# Altium schematic sheet CPU.SchDoc(CPU)
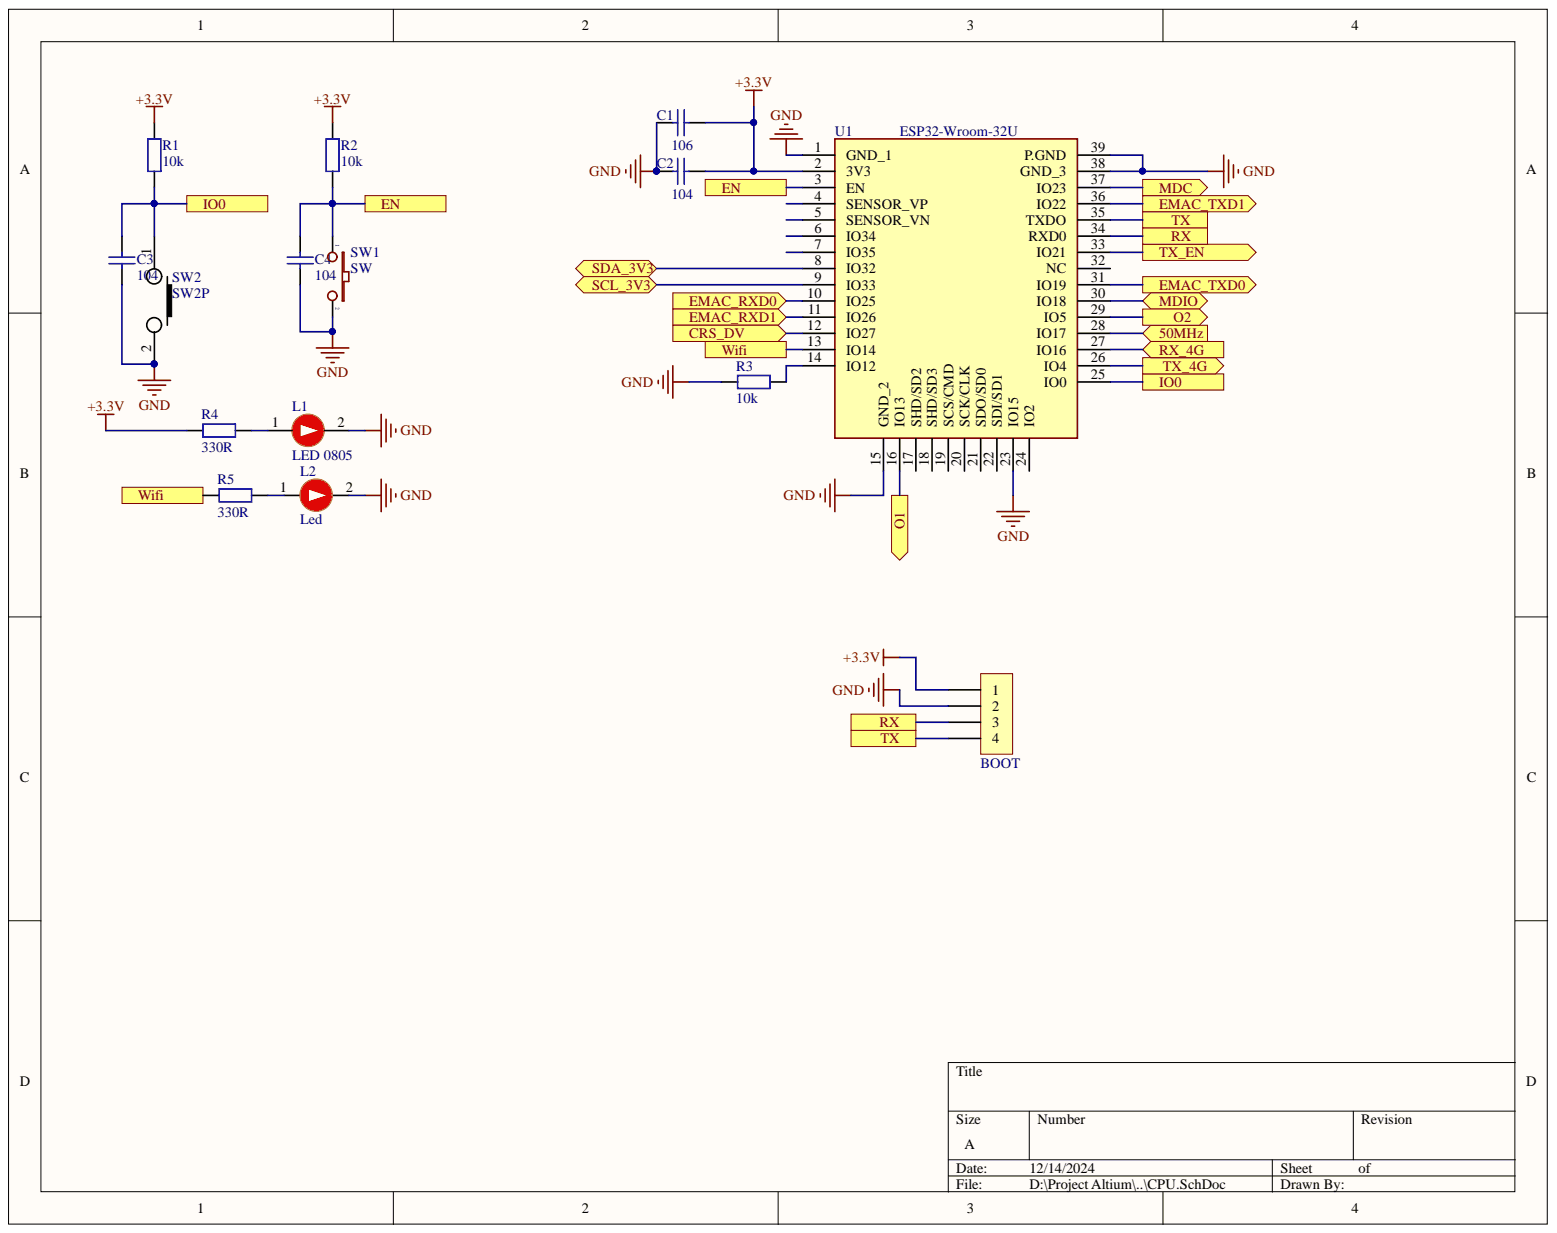
[diagram p0: CPU.SchDoc]

## Parts
C1  106  [tu 0805]
C2  104  [tu 0603]
C3  104  [tu 0603]
C4  104  [tu 0603]
H1  BOOT  [XH2.54M-4]
L1  LED 0805  [LED-0805-Red]
L2  Led  [Led housing]
R1  10k  [tro smd 0603]
R2  10k  [tro smd 0603]
R3  10k  [tro smd 0603]
R4  330R  [tro smd 0603]
R5  330R  [tro smd 0603]
SW1  SW  [SW1-DIP]
SW2  SW2P  [SW_2P_smd]
U1  ESP32-Wroom-32U  [XCVR_ESP32-WROOM-32U]

## Ports
50MHz, CRS_DV, EMAC_RXD0, EMAC_RXD1, EMAC_TXD0, EMAC_TXD1, EN, IO0, MDC, MDIO, O1, O2, RX, RX_4G, SCL_3V3, SDA_3V3, TX, TX_4G, TX_EN, Wifi

## Nets
+3.3V: C1.1 C2.2 H1.1 R1.2 R2.2 R4.2 U1.2(3V3)
GND: C1.2 C2.1 C3.2 C4.2 H1.2 L1.2 L2.2 R3.1 SW1.2 SW2.2 U1.1(GND_1) U1.15(GND_2) U1.23(IO15) U1.38(GND_3) U1.39(P.GND)
50MHz: U1.28(IO17) ; port 50MHz
CRS_DV: U1.12(IO27) ; port CRS_DV
EMAC_RXD0: U1.10(IO25) ; port EMAC_RXD0
EMAC_RXD1: U1.11(IO26) ; port EMAC_RXD1
EMAC_TXD0: U1.31(IO19) ; port EMAC_TXD0
EMAC_TXD1: U1.36(IO22) ; port EMAC_TXD1
EN: C4.1 R2.1 SW1.1 U1.3(EN) ; port EN
IO0: C3.1 R1.1 SW2.1 U1.25(IO0) ; port IO0
MDC: U1.37(IO23) ; port MDC
MDIO: U1.30(IO18) ; port MDIO
O1: U1.16(IO13) ; port O1
O2: U1.29(IO5) ; port O2
RX: H1.3 U1.34(RXD0) ; port RX
RX_4G: U1.27(IO16) ; port RX_4G
SCL_3V3: U1.9(IO33) ; port SCL_3V3
SDA_3V3: U1.8(IO32) ; port SDA_3V3
TX: H1.4 U1.35(TXDO) ; port TX
TX_4G: U1.26(IO4) ; port TX_4G
TX_EN: U1.33(IO21) ; port TX_EN
Wifi: R5.2 U1.13(IO14) ; port Wifi
NetL1_1: L1.1 R4.1
NetL2_1: L2.1 R5.1
NetR3_2: R3.2 U1.14(IO12)

Not connected: U1 4(SENSOR_VP) 5(SENSOR_VN) 6(IO34) 7(IO35) 17(SHD/SD2) 18(SHD/SD3) 19(SCS/CMD) 20(SCK/CLK) 21(SDO/SD0) 22(SDI/SD1) 24(IO2) 32(NC)

## Library data of the parts
C1 = Tu 0805 106 16V 10%: Dán; Ten_Linh_Kien: Tụ 0805 106-10uF 10% 16V; So_Chan: 2; Ma_Hang: MH004553; Don_Vi: Con; Library Name: Tu dien.SchLib; Component Kind: Standard; Chung_Loai: Dán
C2-C4 = Tu 0603 104 50V 10%: Dán; Ten_Linh_Kien: Tụ 0603 104-100nF 10% 50V; So_Chan: 2; Ma_Hang: MH004568; Don_Vi: Con; Library Name: Tu dien.SchLib; Component Kind: Standard; Chung_Loai: Dán
H1 = Header 4P 2.54 Duc Thang: Cắm; Ten_Linh_Kien: Header 4P XH2.54-4P Đực Thẳng; Ma_Hang: MH001285; Don_Vi: Con; Library Name: Header.SchLib; Component Kind: Standard; Chung_Loai: Cắm
L1 = LED 0805 Do: Dán; Ten_Linh_Kien: LED 0805 Màu Đỏ (Red); Ma_Hang: MH000897; Don_Vi: Con; Library Name: Led.SchLib; Component Kind: Standard; Chung_Loai: Dán
L2 = Led housing: Library Name: test.SchLib; Component Kind: Standard
R1 R2 = Tro 0603 1% 10k: Dán; Ten_Linh_Kien: Trở 0603 1% 10k; So_Chan: 2; Ma_Hang: MH006333; Don_Vi: Con; Library Name: Dien Tro.SchLib; Component Kind: Standard; Chung_Loai: Dán
R3 = Tro 0603 5% 10k: Dán; Ten_Linh_Kien: Trở 0603 5% 10k; So_Chan: 2; Ma_Hang: MH006316; Don_Vi: Con; Library Name: Dien Tro.SchLib; Component Kind: Standard; Chung_Loai: Dán
R4 R5 = Tro 0603 5% 330R: Dán; Ten_Linh_Kien: Trở 0603 5% 330R; So_Chan: 2; Ma_Hang: MH006910; Don_Vi: Con; Library Name: Dien Tro.SchLib; Component Kind: Standard; Chung_Loai: Dán
SW1 = SW2: Switch button 2 pin; Value: SW chip 2 pin; Library Name: TechMT.VN_Lib_Project v2.IntLib; Component Kind: Standard
SW2 = sw_2p_smd: Library Name: test.SchLib; Component Kind: Standard
U1 = ESP32-Wroom-32U: Library Name: test.SchLib; Component Kind: Standard
